# Altium schematic sheet [1]MCU.SchDoc([1]MCU)
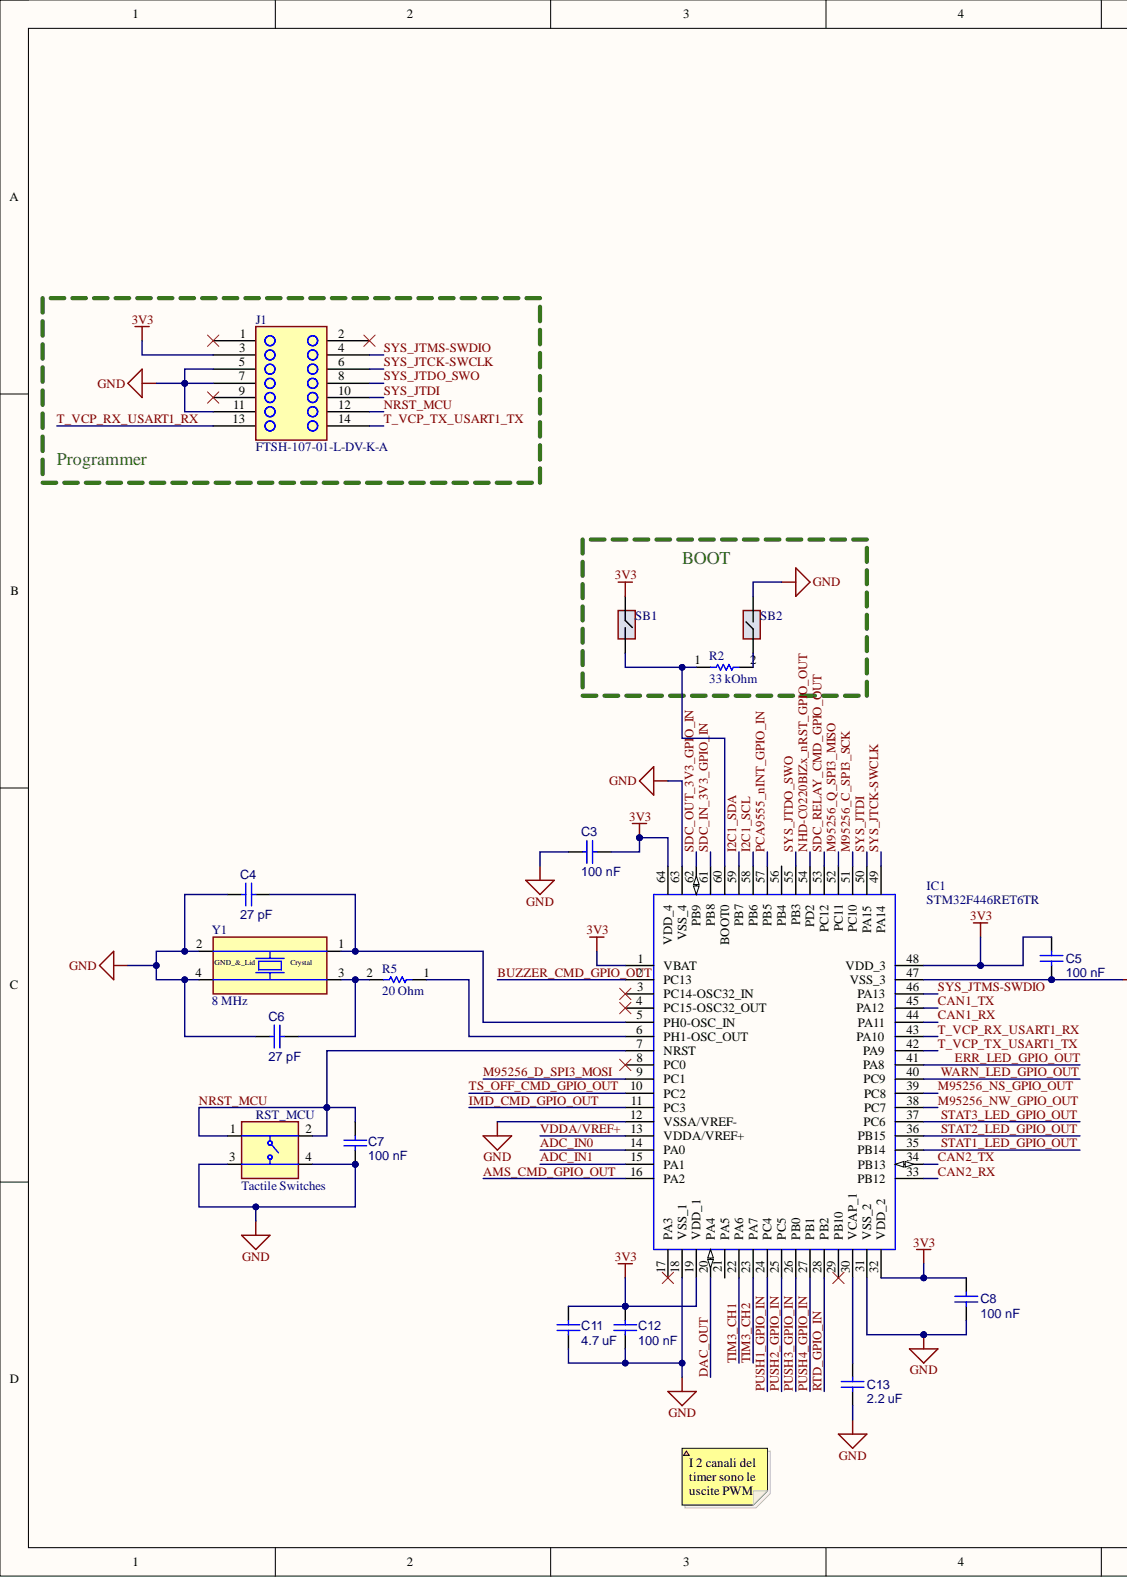
[diagram p0: [1]MCU.SchDoc, left]
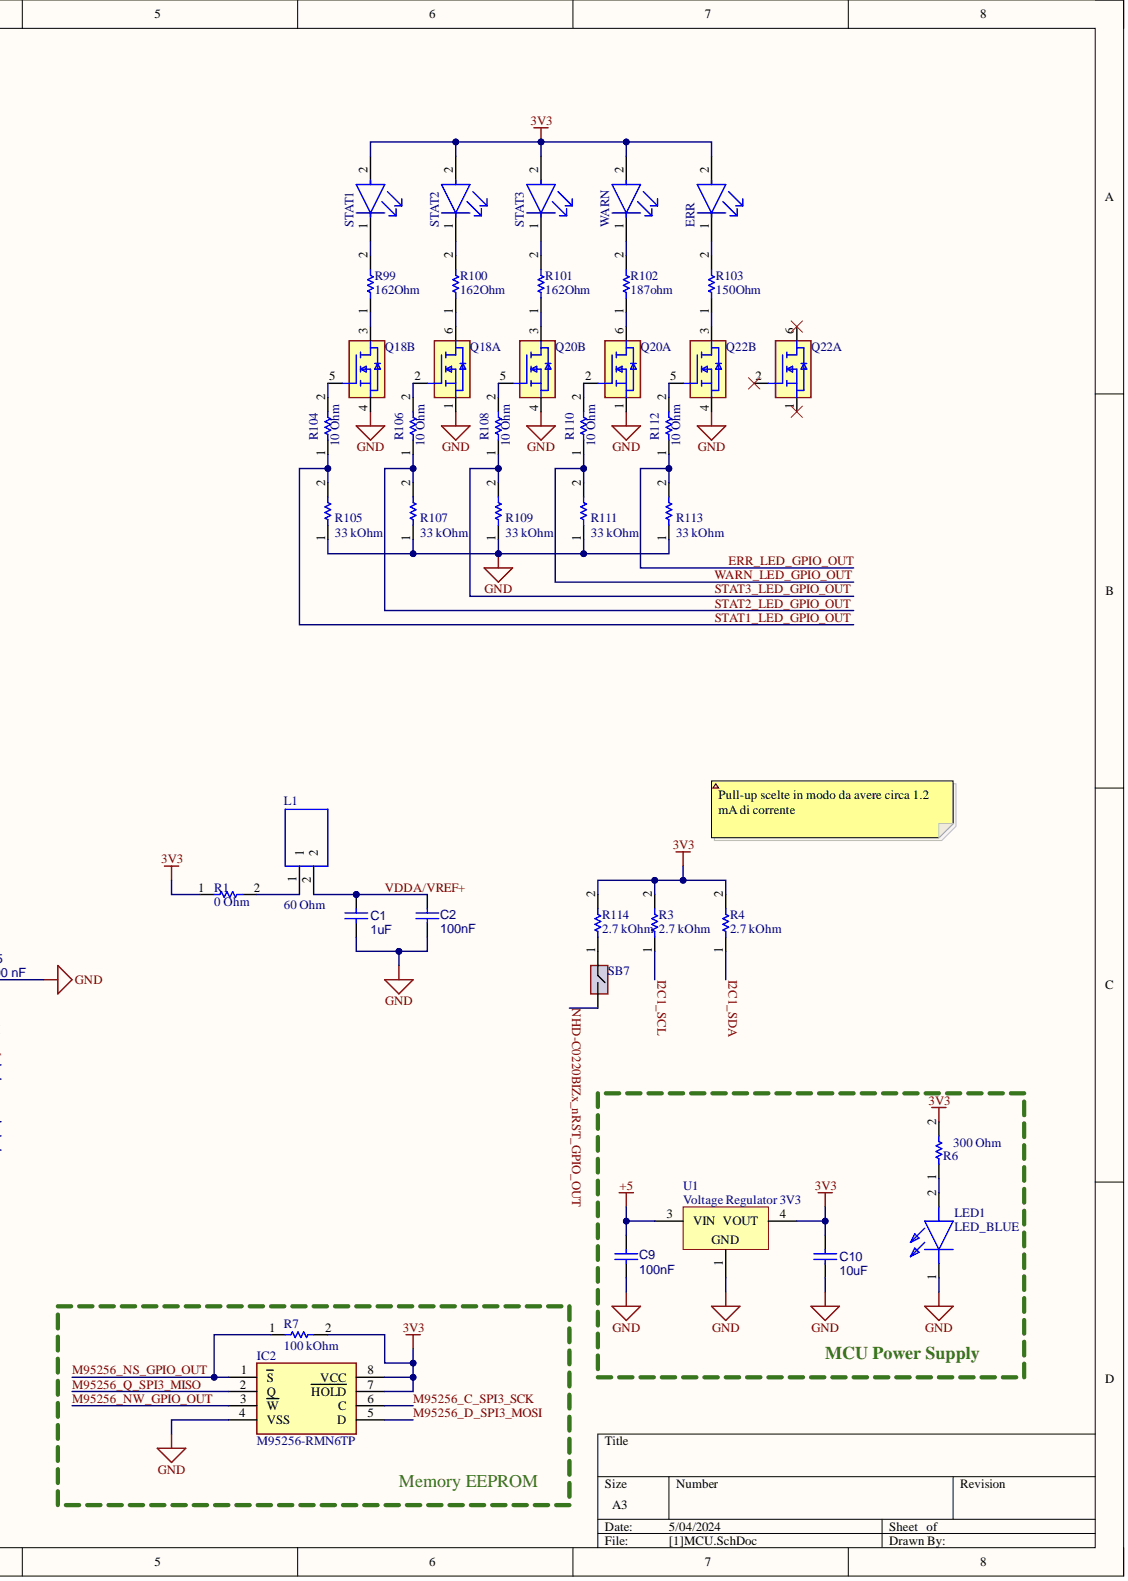
[diagram p1: [1]MCU.SchDoc, right]

## Parts
C1  1uF
C2  100nF
C3  100 nF
C4  27 pF
C5  100 nF
C6  27 pF
C7  100 nF
C8  100 nF
C9  100nF
C10  10uF
C11  4.7 uF
C12  100 nF
C13  2.2 uF
ERR  RED  (value not drawn)
IC1  STM32F446RET6TR
IC2  M95256-RMN6TP
J1  FTSH-107-01-L-DV-K-A
L1  60 Ohm
LED1  LED_BLUE
Q18  SI1926DL-T1-GE3  (value not drawn)  (parts A,B)
Q20  SI1926DL-T1-GE3  (value not drawn)  (parts A,B)
Q22  SI1926DL-T1-GE3  (value not drawn)  (parts A,B)
R1  0 Ohm
R2  33 kOhm
R3  2.7 kOhm
R4  2.7 kOhm
R5  20 Ohm
R6  300 Ohm
R7  100 kOhm
R99  162Ohm
R100  162Ohm
R101  162Ohm
R102  187ohm
R103  150Ohm
R104  10 Ohm
R105  33 kOhm
R106  10 Ohm
R107  33 kOhm
R108  10 Ohm
R109  33 kOhm
R110  10 Ohm
R111  33 kOhm
R112  10 Ohm
R113  33 kOhm
R114  2.7 kOhm
RST_MCU  Tactile Switches
SB1  SolderBridge_0805  (value not drawn)  (no BOM)
SB2  SolderBridge_0805  (value not drawn)  (no BOM)
SB7  SolderBridge_0805  (value not drawn)  (no BOM)
STAT1  GREEN  (value not drawn)
STAT2  GREEN  (value not drawn)
STAT3  GREEN  (value not drawn)
U1  Voltage Regulator 3V3
WARN  YELLOW  (value not drawn)
Y1  8 MHz

## Nets
3V3: C3.1 C5.2 C8.2 C10.2 C11.2 C12.2 ERR.2 IC1.1(VBAT) IC1.19(VDD_1) IC1.32(VDD_2) IC1.48(VDD_3) IC1.64(VDD_4) IC2.7(HOLD) IC2.8(VCC) J1.3 R1.1 R3.2 R4.2 R6.2 R7.2 R114.2 SB1.1 STAT1.2 STAT2.2 STAT3.2 U1.4 WARN.2
+5: C9.2 U1.3
GND: C1.1 C2.1 C3.2 C4.2 C5.1 C6.2 C7.2 C8.1 C9.1 C10.1 C11.1 C12.1 C13.1 IC1.12(VSSA/VREF-) IC1.18(VSS_1) IC1.31(VSS_2) IC1.47(VSS_3) IC1.63(VSS_4) IC2.4(VSS) J1.5 J1.7 J1.11 LED1.1 Q18.1 Q18.4 Q20.1 Q20.4 Q22.4 R105.1 R107.1 R109.1 R111.1 R113.1 RST_MCU.3 RST_MCU.4 SB2.2 U1.1 Y1.2 Y1.4
ADC_IN0: IC1.14(PA0)
ADC_IN1: IC1.15(PA1)
AMS_CMD_GPIO_OUT: IC1.16(PA2)
BUZZER_CMD_GPIO_OUT: IC1.2(PC13)
CAN1_RX: IC1.44(PA11)
CAN1_TX: IC1.45(PA12)
CAN2_RX: IC1.33(PB12)
CAN2_TX: IC1.34(PB13)
DAC_OUT: IC1.20(PA4)
ERR_LED_GPIO_OUT: IC1.41(PA8) R112.1 R113.2
I2C1_SCL: IC1.58(PB6) R3.1
I2C1_SDA: IC1.59(PB7) R4.1
IMD_CMD_GPIO_OUT: IC1.11(PC3)
M95256_C_SPI3_SCK: IC1.51(PC10) IC2.6(C)
M95256_D_SPI3_MOSI: IC1.9(PC1) IC2.5(D)
M95256_NS_GPIO_OUT: IC1.39(PC8) IC2.1(S) R7.1
M95256_NW_GPIO_OUT: IC1.38(PC7) IC2.3(W)
M95256_Q_SPI3_MISO: IC1.52(PC11) IC2.2(Q)
NHD-C0220BIZx_nRST_GPIO_OUT: IC1.54(PD2) SB7.2
NRST_MCU: C7.1 IC1.7(NRST) J1.12 RST_MCU.1 RST_MCU.2
PCA9555_nINT_GPIO_IN: IC1.57(PB5)
PUSH1_GPIO_IN: IC1.24(PC4)
PUSH2_GPIO_IN: IC1.25(PC5)
PUSH3_GPIO_IN: IC1.26(PB0)
PUSH4_GPIO_IN: IC1.27(PB1)
RTD_GPIO_IN: IC1.28(PB2)
SDC_IN_3V3_GPIO_IN: IC1.61(PB8)
SDC_OUT_3V3_GPIO_IN: IC1.62(PB9)
SDC_RELAY_CMD_GPIO_OUT: IC1.53(PC12)
STAT1_LED_GPIO_OUT: IC1.35(PB14) R104.1 R105.2
STAT2_LED_GPIO_OUT: IC1.36(PB15) R106.1 R107.2
STAT3_LED_GPIO_OUT: IC1.37(PC6) R108.1 R109.2
SYS_JTCK-SWCLK: IC1.49(PA14) J1.6
SYS_JTDI: IC1.50(PA15) J1.10
SYS_JTDO_SWO: IC1.55(PB3) J1.8
SYS_JTMS-SWDIO: IC1.46(PA13) J1.4
T_VCP_RX_USART1_RX: IC1.43(PA10) J1.13
T_VCP_TX_USART1_TX: IC1.42(PA9) J1.14
TIM3_CH1: IC1.22(PA6)
TIM3_CH2: IC1.23(PA7)
TS_OFF_CMD_GPIO_OUT: IC1.10(PC2)
VDDA/VREF+: C1.2 C2.2 IC1.13(VDDA/VREF+) L1.2
WARN_LED_GPIO_OUT: IC1.40(PC9) R110.1 R111.2
NetC4_1: C4.1 IC1.5(PH0-OSC_IN) Y1.1
NetC6_1: C6.1 R5.2 Y1.3
NetC13_2: C13.2 IC1.30(VCAP_1)
NetERR_1: ERR.1 R103.2
NetIC1_6: IC1.6(PH1-OSC_OUT) R5.1
NetIC1_60: IC1.60(BOOT0) R2.1 SB1.2
NetL1_1: L1.1 R1.2
NetLED1_2: LED1.2 R6.1
NetQ18_2: Q18.2 R106.2
NetQ18_3: Q18.3 R99.1
NetQ18_5: Q18.5 R104.2
NetQ18_6: Q18.6 R100.1
NetQ20_2: Q20.2 R110.2
NetQ20_3: Q20.3 R101.1
NetQ20_5: Q20.5 R108.2
NetQ20_6: Q20.6 R102.1
NetQ22_3: Q22.3 R103.1
NetQ22_5: Q22.5 R112.2
NetR2_2: R2.2 SB2.1
NetR99_2: R99.2 STAT1.1
NetR100_2: R100.2 STAT2.1
NetR101_2: R101.2 STAT3.1
NetR102_2: R102.2 WARN.1
NetR114_1: R114.1 SB7.1

Not connected: IC1 3(PC14-OSC32_IN) 4(PC15-OSC32_OUT) 8(PC0) 17(PA3) 21(PA5) 29(PB10) 56(PB4); J1 1 2 9; Q22 1 2 6

## Library data of the parts
C1 = CMP-001-00085-5: VJ0805Y105KXXTW1BC; Tech: SMD; Component Kind: Standard; ComponentLink1Description: Website; Package: 0805; Library Name: EMEA- Squadra Corse PoliTO-  Politecnico di Torino
C2 C9 = CMP-001-00085-5: VJ0805Y104KBABE31; Tech: SMD; Component Kind: Standard; ComponentLink1Description: Website; Package: 0805; Library Name: EMEA- Squadra Corse PoliTO-  Politecnico di Torino
C3 C5 C7 C8 C12 = CMP-001-00085-5: VJ0805Y104MXATW1BC; Tech: SMD; Component Kind: Standard; ComponentLink1Description: Website; Package: 0805; Library Name: EMEA- Squadra Corse PoliTO-  Politecnico di Torino
C4 C6 = CMP-001-00085-5: VJ0805A270FXXPW1BC; Tech: SMD; Component Kind: Standard; ComponentLink1Description: Website; Package: 0805; Library Name: EMEA- Squadra Corse PoliTO-  Politecnico di Torino
C10 = CMP-001-00085-5: GRM21BR71A106KA73K; Tech: SMD; Component Kind: Standard; ComponentLink1Description: Website; Package: 0805; Library Name: EMEA- Squadra Corse PoliTO-  Politecnico di Torino
C11 = CMP-001-00085-5: VJ0805G475KXQTW1BC; Tech: SMD; Component Kind: Standard; ComponentLink1Description: Website; Package: 0805; Library Name: EMEA- Squadra Corse PoliTO-  Politecnico di Torino
C13 = CMP-001-00085-5: VJ0805Y225KXJTW1BC; Tech: SMD; Component Kind: Standard; ComponentLink1Description: Website; Package: 0805; Library Name: EMEA- Squadra Corse PoliTO-  Politecnico di Torino
ERR = CMP-007-00036-2: VLMS1300-GS08; Tech: SMD; Component Kind: Standard; Package: 0603; Library Name: EMEA- Squadra Corse PoliTO-  Politecnico di Torino
IC1 = CMP-002-000014-2: 32-bit Microcontrollers - MCU 16/32-BITS MICROS; Manufacturer_Name: STMicroelectronics; Geometry.Height: 1.6mm; Component Kind: Standard; Datasheet Link: https://www.arrow.com/en/products/stm32f446ret6tr/stmicroelectronics; Design Item ID: STM32F446RET6TR; Manufacturer_Part_Number: STM32F446RET6TR; Mouser Part Number: 511-STM32F446RET6TR; Mouser Price/Stock: https://www.mouser.co.uk/ProductDetail/STMicroelectronics/STM32F446RET6TR?qs=xZ%2FP%252Ba9zWqYaBJrnMqQZtg%3D%3D; Source: STM32F446RET6TR.SchLib; Library Name: EMEA- Squadra Corse PoliTO-  Politecnico di Torino
IC2 = CMP-007-000006-1: 256-Kbit serial SPI bus EEPROM with high-speed clock; Manufacturer_Name: STMicroelectronics; Arrow Part Number: M95256-RMN6TP; Arrow Price/Stock: https://www.arrow.com/en/products/m95256-rmn6tp/stmicroelectronics; Component Kind: Standard; Datasheet Link: https://datasheet.lcsc.com/szlcsc/1812011607_STMicroelectronics-M95256-RMN6TP_C140883.pdf; Design Item ID: M95256-RMN6TP; Height: 1.75mm; Manufacturer_Part_Number: M95256-RMN6TP; Mouser Part Number: 511-M95256-RMN6TP; Mouser Price/Stock: https://www.mouser.co.uk/ProductDetail/STMicroelectronics/M95256-RMN6TP?qs=6hSH1YZbOIgt2lfjA1S%252B0g%3D%3D; Source: SamacSys.SchLib; Library Name: EMEA- Squadra Corse PoliTO-  Politecnico di Torino
J1 = CMP-00004-4: Samtec Header, 14 Pin, 1.27mm pitch; Component Kind: Standard; Library Name: EMEA- Squadra Corse PoliTO-  Politecnico di Torino
L1 = CMP-056-000000-2: Ferrite Beads Multi-Layer High Current 60Ohm 25% 100MHz 3A 30mOhm DCR 0805 T/R; Manufacturer_Name: Vishay; Manufacturer_Part_Number: ILHB0805ER600V; Geometry.Height: 1.1mm; Component Kind: Standard; Datasheet Link: https://www.arrow.com/en/products/ilhb0805er600v/vishay; Design Item ID: ILHB0805ER600V; Mouser Part Number: 70-ILHB0805ER600V; Mouser Price/Stock: https://www.mouser.co.uk/ProductDetail/Vishay-Dale/ILHB0805ER600V?qs=nDC8RkArV2CRAw2z9yWdfQ%3D%3D; Source: ILHB0805ER600V.SchLib; Library Name: EMEA- Squadra Corse PoliTO-  Politecnico di Torino
LED1 = CMP-031-000016-1: APT2012LVBC_D; Manufacturer_Name: Kingbright; Manufacturer_Part_Number: APT2012LVBC/D; Tech: SMD; Arrow Part Number: APT2012LVBC/D; Arrow Price/Stock: https://www.arrow.com/en/products/apt2012lvbcd/kingbright; Component Kind: Standard; ComponentLink1Description: Website; ComponentLink1URL: https://www.mouser.it/ProductDetail/Kingbright/APT2012LVBC-D?qs=6oMev5NRZMGErJYT6KZLXg%3D%3D; Datasheet Link: https://componentsearchengine.com/Datasheets/1/APT2012LVBC_D.pdf; Design Item ID: APT2012LVBC_D; Height: 0.85mm; Mouser Part Number: 604-APT2012LVBCD; Mouser Price/Stock: https://www.mouser.co.uk/ProductDetail/Kingbright/APT2012LVBC-D?qs=6oMev5NRZMGErJYT6KZLXg%3D%3D; Package: 0805; Source: SamacSys.SchLib; Library Name: EMEA- Squadra Corse PoliTO-  Politecnico di Torino
Q18 Q20 Q22 = CMP-009-000004-2: MOSFET (N-Channel); Manufacturer_Name: Vishay; Arrow Part Number: SI1926DL-T1-GE3; Arrow Price/Stock: https://www.arrow.com/en/products/si1926dl-t1-ge3/vishay; Component Kind: Standard; Datasheet Link: https://www.vishay.com/docs/73684/si1926dl.pdf; Design Item ID: SI1926DL-T1-GE3; Height: 1.1mm; Manufacturer_Part_Number: SI1926DL-T1-GE3; Mouser Part Number: 78-SI1926DL-T1-GE3; Mouser Price/Stock: https://www.mouser.com/Search/Refine.aspx?Keyword=78-SI1926DL-T1-GE3; Source: SamacSys.SchLib; Library Name: EMEA- Squadra Corse PoliTO-  Politecnico di Torino
R1 = CMP-009-00179-5: CRCW12060000Z0EC; Tech: SMD; Component Kind: Standard; ComponentLink1Description: Website; Package: 0603; Library Name: EMEA- Squadra Corse PoliTO-  Politecnico di Torino
R2 R105 R107 R109 R111 R113 = CMP-009-00179-5: RCS060333K0FKEA; Tech: SMD; Component Kind: Standard; ComponentLink1Description: Website; Package: 0603; Library Name: EMEA- Squadra Corse PoliTO-  Politecnico di Torino
R3 R4 R114 = CMP-00010-3: CRCW06032K70FKEAHP; Component Kind: Standard; Library Name: EMEA- Squadra Corse PoliTO-  Politecnico di Torino
R5 = CMP-009-00179-5: CRCW060320R0FKEAC; Tech: SMD; Component Kind: Standard; ComponentLink1Description: Website; Package: 0603; Library Name: EMEA- Squadra Corse PoliTO-  Politecnico di Torino
R6 = CMP-009-00179-5: CRCW0603300RFKEA; Tech: SMD; Component Kind: Standard; ComponentLink1Description: Website; Package: 0603; Library Name: EMEA- Squadra Corse PoliTO-  Politecnico di Torino
R7 = CMP-00010-3: RT0603FRE13100KL; Component Kind: Standard; Library Name: EMEA- Squadra Corse PoliTO-  Politecnico di Torino
R99-R101 = CMP-009-00179-5: CRCW0603162RFKEA; Tech: SMD; Component Kind: Standard; ComponentLink1Description: Website; Package: 0603; Library Name: EMEA- Squadra Corse PoliTO-  Politecnico di Torino
R102 = CMP-009-00179-5: CRCW0603187RFKEA; Tech: SMD; Component Kind: Standard; ComponentLink1Description: Website; Package: 0603; Library Name: EMEA- Squadra Corse PoliTO-  Politecnico di Torino
R103 = CMP-009-00179-5: CRCW0603150RFKEAHP; Tech: SMD; Component Kind: Standard; ComponentLink1Description: Website; Package: 0603; Library Name: EMEA- Squadra Corse PoliTO-  Politecnico di Torino
R104 R106 R108 R110 R112 = CMP-009-00179-5: CRCW060310R0FKEAHP; Tech: SMD; Component Kind: Standard; ComponentLink1Description: Website; Package: 0603; Library Name: EMEA- Squadra Corse PoliTO-  Politecnico di Torino
RST_MCU = CMP-011-000004-5: RKC2SJF170SMTR_LFS; Manufacturer_Name: C & K COMPONENTS; Manufacturer_Part_Number: RKC2SJF170SMTR LFS; Arrow Part Number: RKC2SJF170SMTR LFS; Arrow Price/Stock: https://www.arrow.com/en/products/rkc2sjf170smtr-lfs/ck?region=nac; Component Kind: Standard; Datasheet Link: https://www.ckswitches.com/media/3470/ck_rkc2_datasheet.pdf; Design Item ID: RKC2SJF170SMTR_LFS; Height: 1.9mm; Mouser Part Number: 611-RKC2SJF170SMRLFS; Mouser Price/Stock: https://www.mouser.co.uk/ProductDetail/CK/RKC2SJF170SMTR-LFS?qs=XAiT9M5g4x%252BRRI9derenng%3D%3D; Source: SamacSys.SchLib; Library Name: EMEA- Squadra Corse PoliTO-  Politecnico di Torino
SB1 SB2 SB7 = CMP-00006-5: 0805 Solder Bridge; Library Name: EMEA- Squadra Corse PoliTO-  Politecnico di Torino
STAT1-STAT3 = CMP-007-00036-2: VLMTG1401-GS08; Tech: SMD; Component Kind: Standard; Package: 0603; Library Name: EMEA- Squadra Corse PoliTO-  Politecnico di Torino
U1 = CMP-0244-00089-1: LD1117DT33CTR; Tech: SMD; Component Kind: Standard; ComponentLink1Description: Manufacturer URL; ComponentLink1URL: http://www.st.com; ComponentLink2Description: Datasheet; ComponentLink2URL: http://www.st.com/internet/com/TECHNICAL_RESOURCES/TECHNICAL_LITERATURE/DATASHEET/CD00000544.pdf; ComponentLink3Description: Website; ComponentLink3URL: https://www.mouser.it/ProductDetail/STMicroelectronics/LD1117DT33CTR?qs=rUxiu3TV3%2FNm%2FOyb7vJzpg%3D%3D; DatasheetVersion: 1/11/2011; Library Name: Altium Content Vault; PackageDescription: 3-Pin Transistor Outline Package 2.28 mm Pitch, 9.7 mm Lead Span (DPAK); PackageReference: TO-252; PackageVersion: 2011
WARN = CMP-007-00036-2: VLMG1300-GS08; Tech: SMD; Component Kind: Standard; Package: 0603; Library Name: EMEA- Squadra Corse PoliTO-  Politecnico di Torino
Y1 = CMP-004-000011-5: 830055663; Manufacturer_Name: Wurth Elektronik; Component Kind: Standard; Datasheet Link: https://www.we-online.com/catalog/datasheet/830055663.pdf; Design Item ID: 830055663; Height: 1mm; Manufacturer_Part_Number: 830055663; Mouser Part Number: 710-830055663; Mouser Price/Stock: https://www.mouser.co.uk/ProductDetail/Wurth-Elektronik/830055663?qs=2WXlatMagcHXGqDkS%2FTHKQ%3D%3D; Source: SamacSys.SchLib; Library Name: EMEA- Squadra Corse PoliTO-  Politecnico di Torino
